# Altium schematic sheet P02 POWER.SchDoc("P02 POWER")
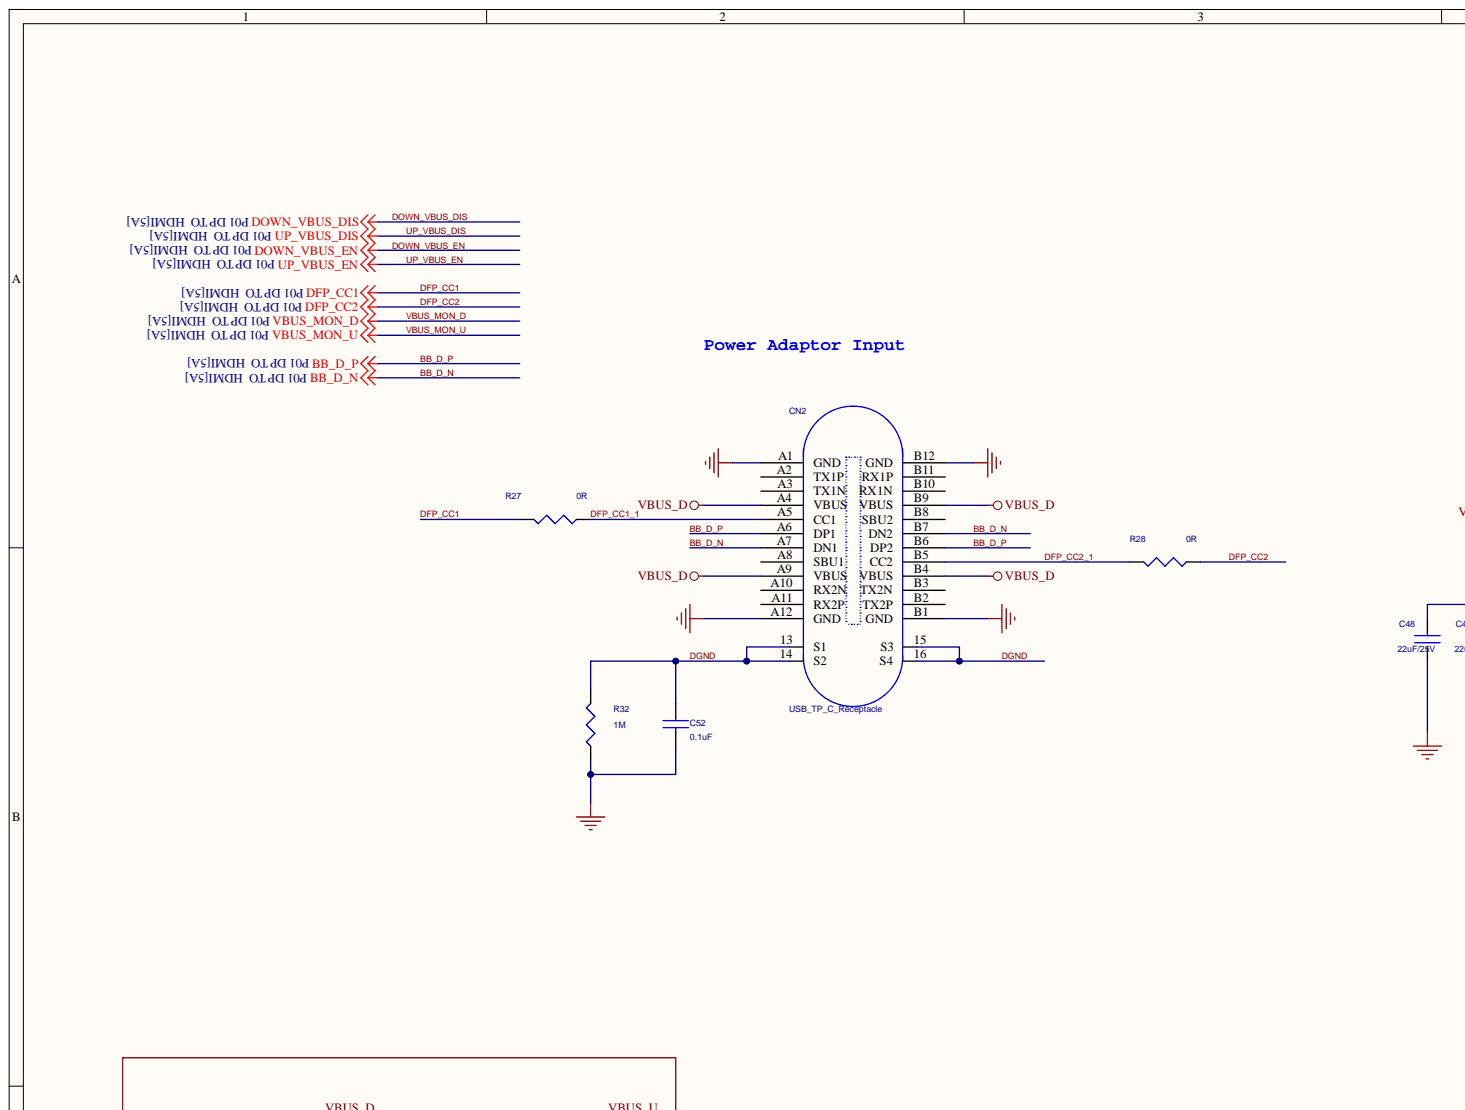
[diagram p0: P02 POWER.SchDoc, top left]
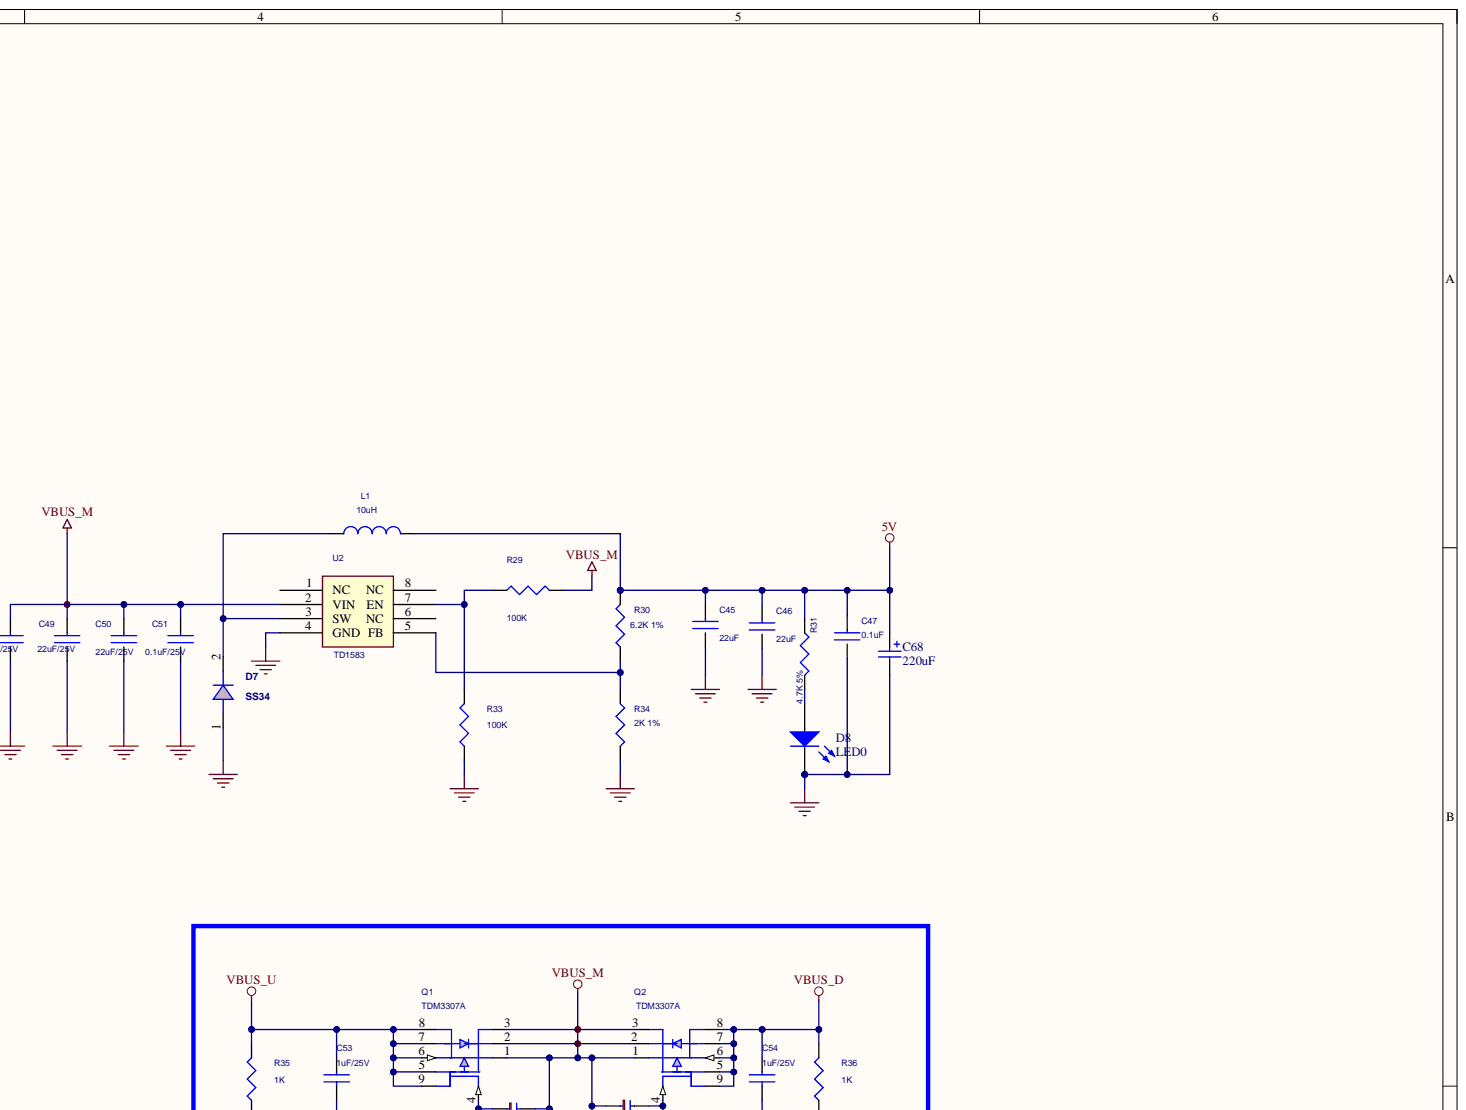
[diagram p1: P02 POWER.SchDoc, top right]
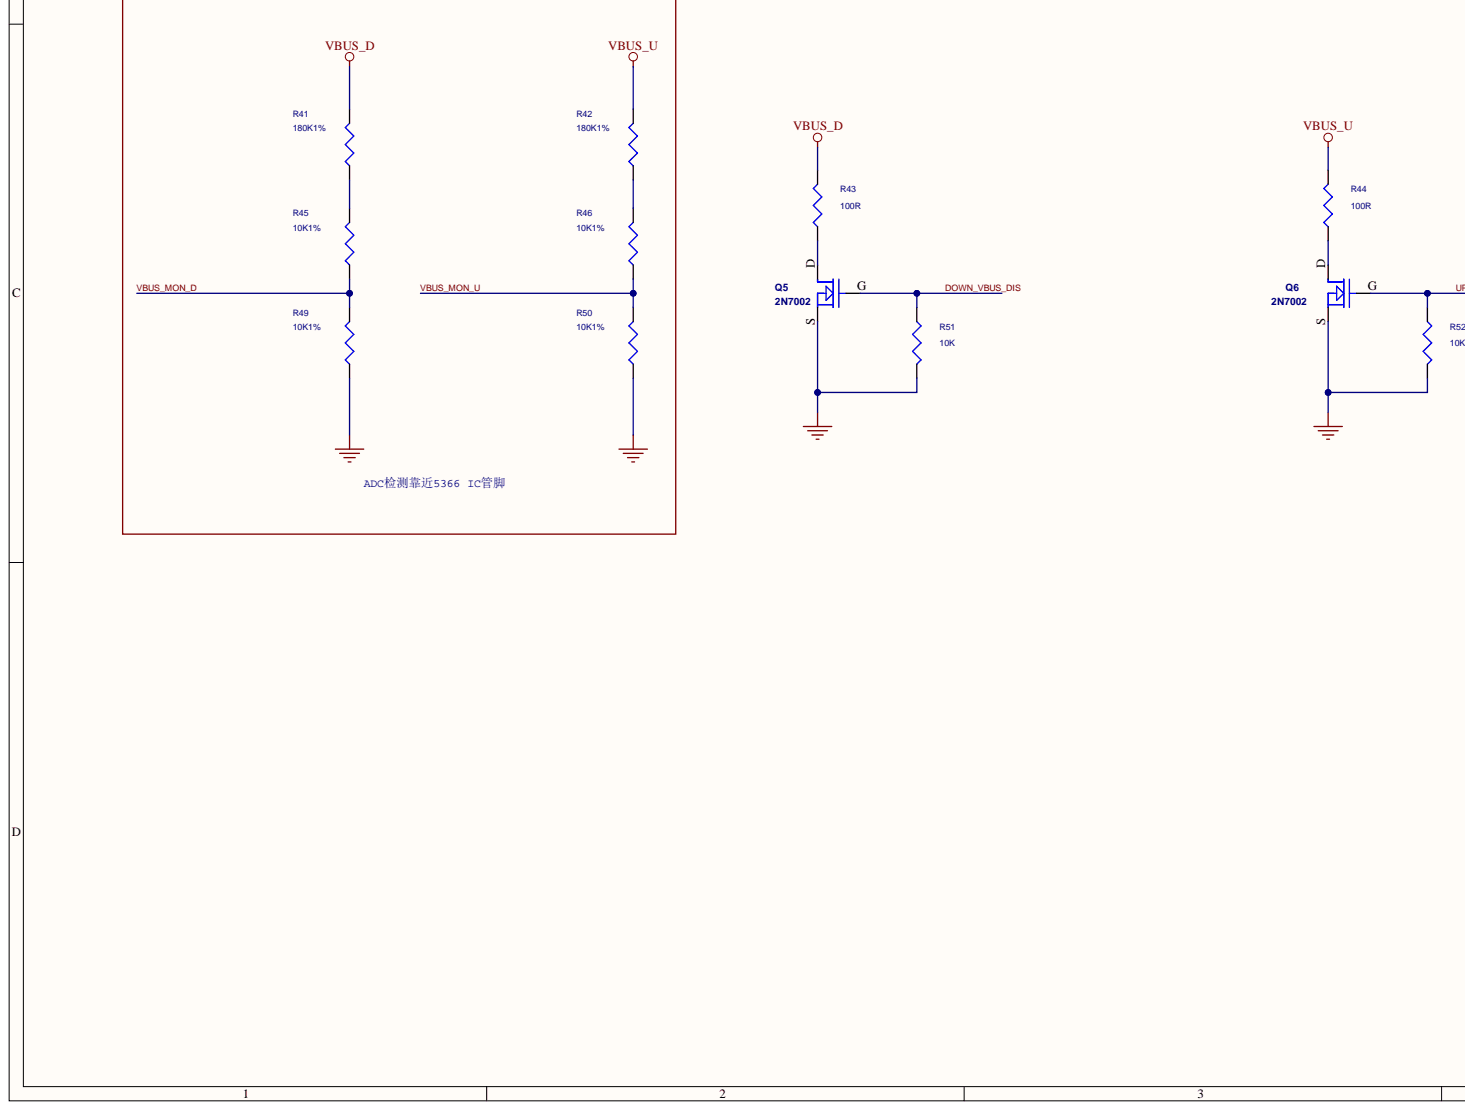
[diagram p2: P02 POWER.SchDoc, bottom left]
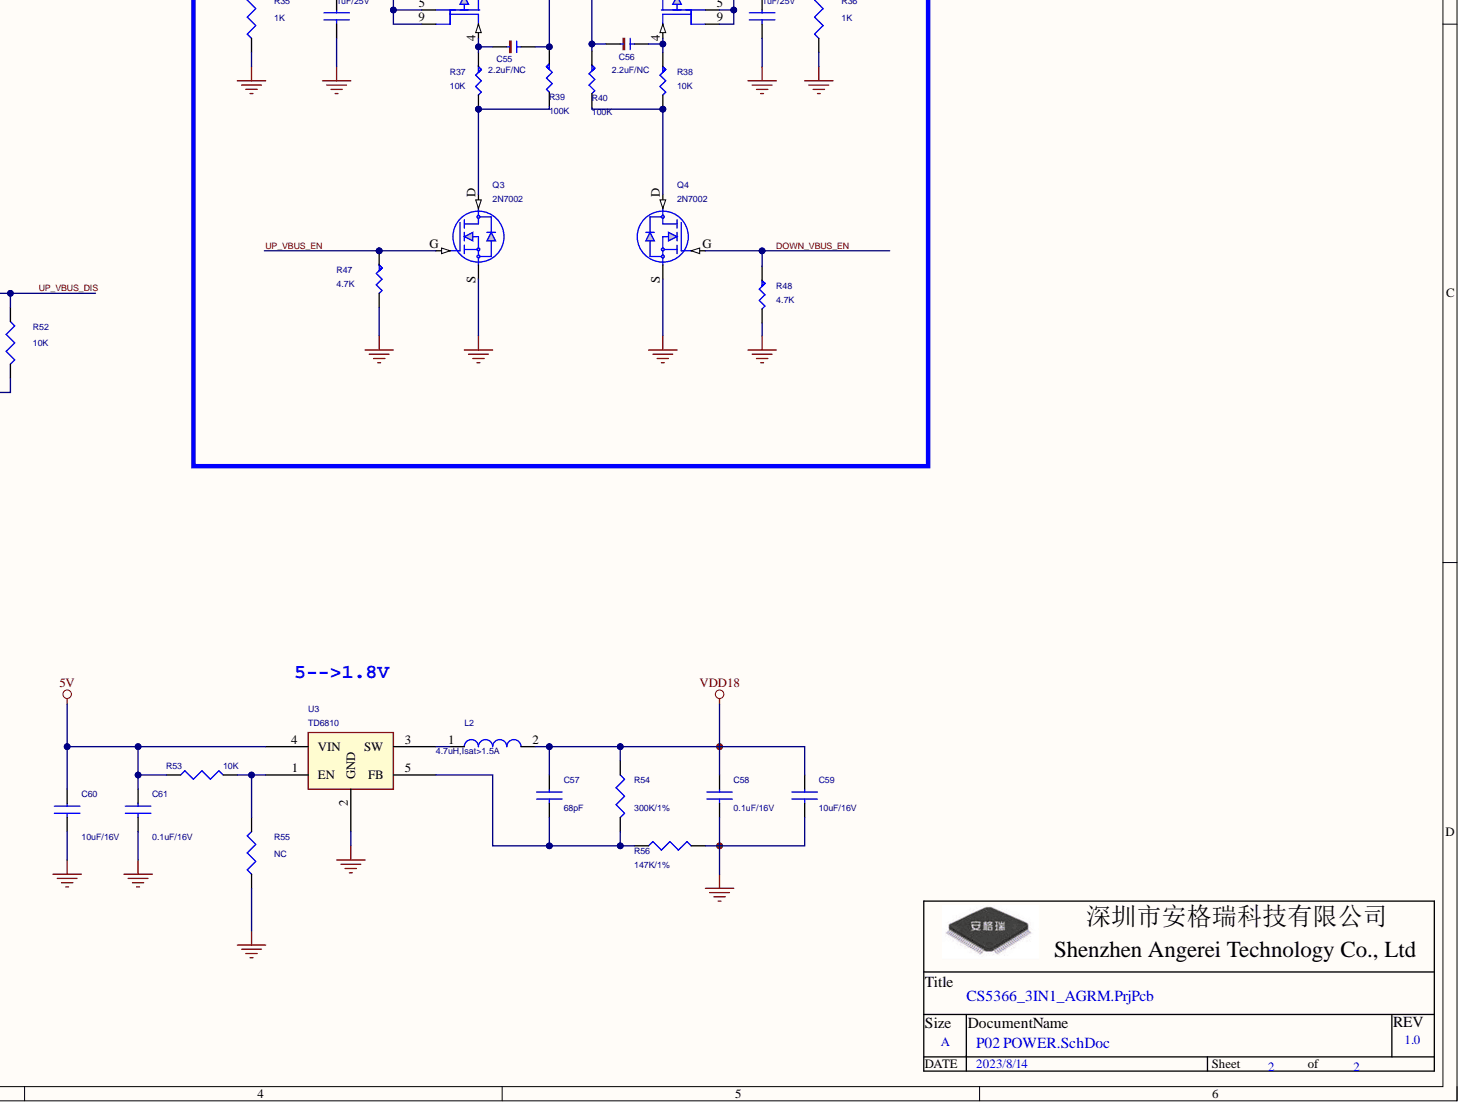
[diagram p3: P02 POWER.SchDoc, bottom right]

## Parts
C45  22uF  [C0603]
C46  22uF  [C0603]
C47  0.1uF  [C0402]
C48  22uF/25V  [C0603]
C49  22uF/25V  [C0603]
C50  22uF/25V  [C0603]
C51  0.1uF/25V  [C0402]
C52  0.1uF  [C0402]
C53  1uF/25V  [C0402]
C54  1uF/25V  [C0402]
C55  2.2uF/NC  [C0402]
C56  2.2uF/NC  [C0402]
C57  68pF  [C0402]
C58  0.1uF/16V  [C0402]
C59  10uF/16V  [C0603]
C60  10uF/16V  [C0603]
C61  0.1uF/16V  [C0402]
C68  value 220uF  [ELECAP_8X12MM]  (hidden comment: Cap Pol2)
CN2  USB_TP_C_Receptacle  [TYPE_C_F_8.5]
D7  SS34  [SK54A-LTP]
D8  LED0  [LED0603]
L1  10uH  [L-0630-7*7]
L2  4.7uH,Isat>1.5A  [SWPA252012S]
Q1  TDM3307A  [DFN33]
Q2  TDM3307A  [DFN33]
Q3  2N7002  [SOT23-GDS]
Q4  2N7002  [SOT23-GDS]
Q5  2N7002  [SOT23-GDS]
Q6  2N7002  [SOT23-GDS]
R27  0R  [R0402]
R28  0R  [R0402]
R29  100K  [R0402]
R30  6.2K 1%  [R0402]
R31  4.7K 5%  [R0402]
R32  1M  [R0402]
R33  100K  [R0402]
R34  2K 1%  [R0402]
R35  1K  [R0402]
R36  1K  [R0402]
R37  10K  [R0402]
R38  10K  [R0402]
R39  100K  [R0402]
R40  100K  [R0402]
R41  180K1%  [R0402]
R42  180K1%  [R0402]
R43  100R  [R0805]
R44  100R  [R0805]
R45  10K1%  [R0402]
R46  10K1%  [R0402]
R47  4.7K  [R0402]
R48  4.7K  [R0402]
R49  10K1%  [R0402]
R50  10K1%  [R0402]
R51  10K  [R0402]
R52  10K  [R0402]
R53  10K  [R0402]
R54  300K/1%  [R0402]
R55  NC  [R0402]
R56  147K/1%  [R0402]
U2  TD1583  [SOP8]
U3  TD6810  [SOT23-5]

## Nets
5V: C45.2 C46.2 C47.2 C60.1 C61.1 C68.1 L1.2 R30.2 R31.1 R53.1 U3.4(VIN)
GND: C45.1 C46.1 C47.1 C48.1 C49.1 C50.1 C51.1 C52.2 C53.2 C54.2 C58.2 C59.2 C60.2 C61.2 C68.2 CN2.A1(GND) CN2.A12(GND) CN2.B1(GND) CN2.B12(GND) D7.1 D8.2 Q3.S Q4.S Q5.S Q6.S R32.1 R33.1 R34.2 R35.1 R36.1 R47.2 R48.2 R49.1 R50.1 R51.2 R52.2 R55.1 R56.2 U2.4(GND) U3.2(GND)
VBUS_D: C54.1 CN2.A4(VBUS) CN2.A9(VBUS) CN2.B4(VBUS) CN2.B9(VBUS) Q2.5 Q2.6 Q2.7 Q2.8 Q2.9 R36.2 R41.2 R43.2
VBUS_M: C48.2 C49.2 C50.2 C51.2 C55.1 C56.2 Q1.1 Q1.2 Q1.3 Q2.1 Q2.2 Q2.3 R29.2 R39.1 R40.1 U2.2(VIN)
VBUS_U: C53.1 Q1.5 Q1.6 Q1.7 Q1.8 Q1.9 R35.2 R42.2 R44.2
VDD18: C57.1 C58.1 C59.1 L2.2 R54.1
BB_D_N: CN2.A7(DN1) CN2.B7(DN2)
BB_D_P: CN2.A6(DP1) CN2.B6(DP2)
DFP_CC1: R27.2
DFP_CC1_1: CN2.A5(CC1) R27.1
DFP_CC2: R28.1
DFP_CC2_1: CN2.B5(CC2) R28.2
DGND: C52.1 CN2.13(S1) CN2.14(S2) CN2.15(S3) CN2.16(S4) R32.2
DOWN_VBUS_DIS: Q5.G R51.1
DOWN_VBUS_EN: Q4.G R48.1
UP_VBUS_DIS: Q6.G R52.1
UP_VBUS_EN: Q3.G R47.1
VBUS_MON_D: R45.1 R49.2
VBUS_MON_U: R46.1 R50.2
NetC55_2: C55.2 Q1.4 R37.1
NetC56_1: C56.1 Q2.4 R38.1
NetC57_2: C57.2 R54.2 R56.1 U3.5(FB)
NetD7_2: D7.2 L1.1 U2.3(SW)
NetD8_1: D8.1 R31.2
NetL2_1: L2.1 U3.3(SW)
NetQ3_D: Q3.D R37.2 R39.2
NetQ4_D: Q4.D R38.2 R40.2
NetQ5_D: Q5.D R43.1
NetQ6_D: Q6.D R44.1
NetR29_1: R29.1 R33.2 U2.7(EN)
NetR30_1: R30.1 R34.1 U2.5(FB)
NetR41_1: R41.1 R45.2
NetR42_1: R42.1 R46.2
NetR53_2: R53.2 R55.2 U3.1(EN)

Not connected: CN2 A2(TX1P) A3(TX1N) A8(SBU1) A10(RX2N) A11(RX2P) B2(TX2P) B3(TX2N) B8(SBU2) B10(RX1N) B11(RX1P); U2 1(NC) 6(NC) 8(NC)

## Library data of the parts
C45 C46 C48-C50 = Cap 0603 10uf 6.3V Ceramic: 0_MANUFACTURER: Taiyo Yuden; 0_PARTNUM: EMK107BJ105KA-TR; Component Kind: Standard
C47 C51 = Cap 0402 0.1uF 16V 10% Ceramic: 0_MANUFACTURER: Taiyo Yuden; 0_PARTNUM: EMK107BJ105KA-TR; Component Kind: Standard
C52 C57-C60 = CAP 0603_5: Component Kind: Standard
C53 C54 = C_7: Component Kind: Standard
C55 C56 = C: Part Number: 99H12-008857; approval status: A; Dsc1: CAP ML; Dsc2: CL21A225KB9LNNC; Dsc3: 2.2 uF; Dsc4: K; Dsc5: 50V; Dsc6: X5R; Dsc7: SMT0805,THICKNESS=0.9∮0.1mm; Dsc8: SAMSUNG; DSCX: CAP ML CL21A225KB9LNNC 2.2 uF K 50V X5R SMT0805,THICKNESS=0.9∮0.1mm SAMSUNG; ID: 35,440; Qualify: YES; QUAN: 455; 弧: CAP ML CL21A225KB9LNNC 2.2 uF; Component Kind: Standard; Cost: 0.02; Date: 12302002; Part Type: SMD CAP
C61 = CAP 0603: Component Kind: Standard
C68 = Cap Pol2: Polarized Capacitor (Axial); Component Kind: Standard; LatestRevisionDate: 17-Jul-2002; LatestRevisionNote: Re-released for DXP Platform.; Library Name: Miscellaneous Devices.IntLib; PackageDescription: Polarized Capacitor; 2 Leads; PackageReference: POLAR0.8; Published: 8-Jun-2000; Publisher: Altium Limited
D7 = DIODE_2: Component Kind: Standard
D8 = LED0: Typical INFRARED GaAs LED; Component Kind: Standard; LatestRevisionDate: 08-Jul-2005; LatestRevisionNote: Mech Layer 1 removed.; Library Name: Miscellaneous Devices.IntLib; PackageDescription: LED; 2 Leads; PackageReference: LED-0; Published: 8-Jun-2000; Simulation: LED0; Publisher: Altium Limited
L1 = Inductor 0603 33nH: 0_MANUFACTURER: Steward; 0_PARTNUM: HZ0805E601R-10; Component Kind: Standard
L2 = INDUCTOR_5: Component Kind: Standard
Q1 Q2 = MOS-P_SO8_1: Part Number: 99-10-030379; approval status: PL; Dsc1: TRANSISTOR FET P CHANNEL; Dsc2: APM4835KC; Dsc3: SOIC; Dsc4: 8PIN; Dsc8: ANPEC; DSCX: TRANSISTOR FET P CHANNEL APM4835KC SOIC 8PIN    ANPEC; ID: 6641; Qualify: YES; QUAN: 0; 弧: TRANSISTOR FET P CHANNEL APM48; Component Kind: Standard; Cost: 0.22; Date: 12302002; Part Type: SMD TRANSISTOR
Q3 = 2N7002_4: Part Number: 99G10-040024; Approval Sheet: \\tp_mi05\BackUp\VPSD\Data Sheet\Data Sheet\99G\99G10-04\99G10-040024.pdf; approval status: A; Dsc1: TRANSISTOR FET N CHANNEL; Dsc3: SOT23; Dsc4: 3PIN; Dsc8: PHILIPS(NXP); DSCX: TRANSISTOR FET N CHANNEL 2N7002 SOT23 3PIN    PHILIPS(NXP); ID: 16007; Qualify: YES; QUAN: 5150; 弧: TRANSISTOR FET N CHANNEL 2N700; Component Kind: Standard; Cost: 0.01; Date: 12302002; Dsc2: 2N7002; Part Type: SMD TRANSISTOR
Q4 = 2N7002_3: Part Number: 99G10-040024; Approval Sheet: \\tp_mi05\BackUp\VPSD\Data Sheet\Data Sheet\99G\99G10-04\99G10-040024.pdf; approval status: A; Dsc1: TRANSISTOR FET N CHANNEL; Dsc3: SOT23; Dsc4: 3PIN; Dsc8: PHILIPS(NXP); DSCX: TRANSISTOR FET N CHANNEL 2N7002 SOT23 3PIN    PHILIPS(NXP); ID: 16007; Qualify: YES; QUAN: 5150; 弧: TRANSISTOR FET N CHANNEL 2N700; Component Kind: Standard; Cost: 0.01; Date: 12302002; Dsc2: 2N7002; Part Type: SMD TRANSISTOR
Q5 Q6 = 2N7002_0: FET MOS 2N7002 NC SOT-23; Part Number: 84.27002.031; Geometry: SOT-23-1; Library: DISCRET1; Mapping file: 2N7002.MAP; QVL: Siliconix; Symbol_cnt: 1; Component Kind: Standard; Symbol: 2N7002
R27 R28 R41 R42 R45 R46 R49 R50 R53 R55 = R_6: Component Kind: Standard
R29-R31 R33 R34 = 0603 0 Ohm 1%: 0_MANUFACTURER: Rohm; 0_PARTNUM: MCR03EZPFX1002; Component Kind: Standard
R32 R35 R36 R43 R44 R51 R52 = R: Component Kind: Standard
R37 R38 = RES: Part Number: 99G20-001681; approval status: NA; Dsc1: RES CHIP; Dsc2: 10K OHM; Dsc3: F; Dsc4: 1/16W; Dsc5: CF; Dsc6: SMT0402,THICKNESS=0.45∮0.10mm; Dsc7: RC0402FR-0710KL; Dsc8: YAGEO; ID: 15204; Qualify: YES; QUAN: 134341; 弧: RES CHIP 10K OHM F 1/16W CF S; Component Kind: Standard; Cost: 0.00; Date: 12302002; Part Type: SMD RES
R39 R40 = RES: Part Number: 99G19-000093; approval status: NA; Dsc1: RES CHIP; Dsc2: 100K OHM; Dsc3: J; Dsc4: 1/10W; Dsc5: CF; Dsc6: SMT0603,THICKNESS=0.45∮0.10mm; Dsc7: RC0603JR-07100KL; Dsc8: YAGEO; DSCX: RES CHIP 100K OHM J 1/10W CF SMT0603,THICKNESS=0.45∮0.10mm RC0603JR-07100KL YAGEO; ID: 17301; Qualify: YES; QUAN: 106862; 弧: RES CHIP 100K OHM J 1/10W CF S; Component Kind: Standard; Cost: 0.00; Date: 12302002; Part Type: SMD RES
R47 R48 = RES: Part Number: 99G19-001443; approval status: A; Dsc1: RES CHIP; Dsc2: RC0402JR-074K7L; Dsc3: 4.7K OHM; Dsc4: J; Dsc5: 1/16W; Dsc6: CF; Dsc7: SMT0402,THICKNESS=0.35∮0.05mm; Dsc8: YAGEO; DSCX: RES CHIP RC0402JR-074K7L 4.7K OHM J 1/16W CF SMT0402,THICKNESS=0.35∮0.05mm YAGEO; ID: 21,879; Qualify: YES; QUAN: 25,654; 弧: RES CHIP 4.7K OHM J 1/16W CF S; Component Kind: Standard; Cost: 0; Date: 12302002; Part Type: SMD RES
R54 R56 = R-0603_12: Component Kind: Standard
U2 = TD1410: Component Kind: Standard
U3 = MIC29302_0: Component Kind: Standard
CN2 = USB_TP_C_Receptacle: Component Kind: Standard
